# Altium schematic sheet Connector.SchDoc(Connector)
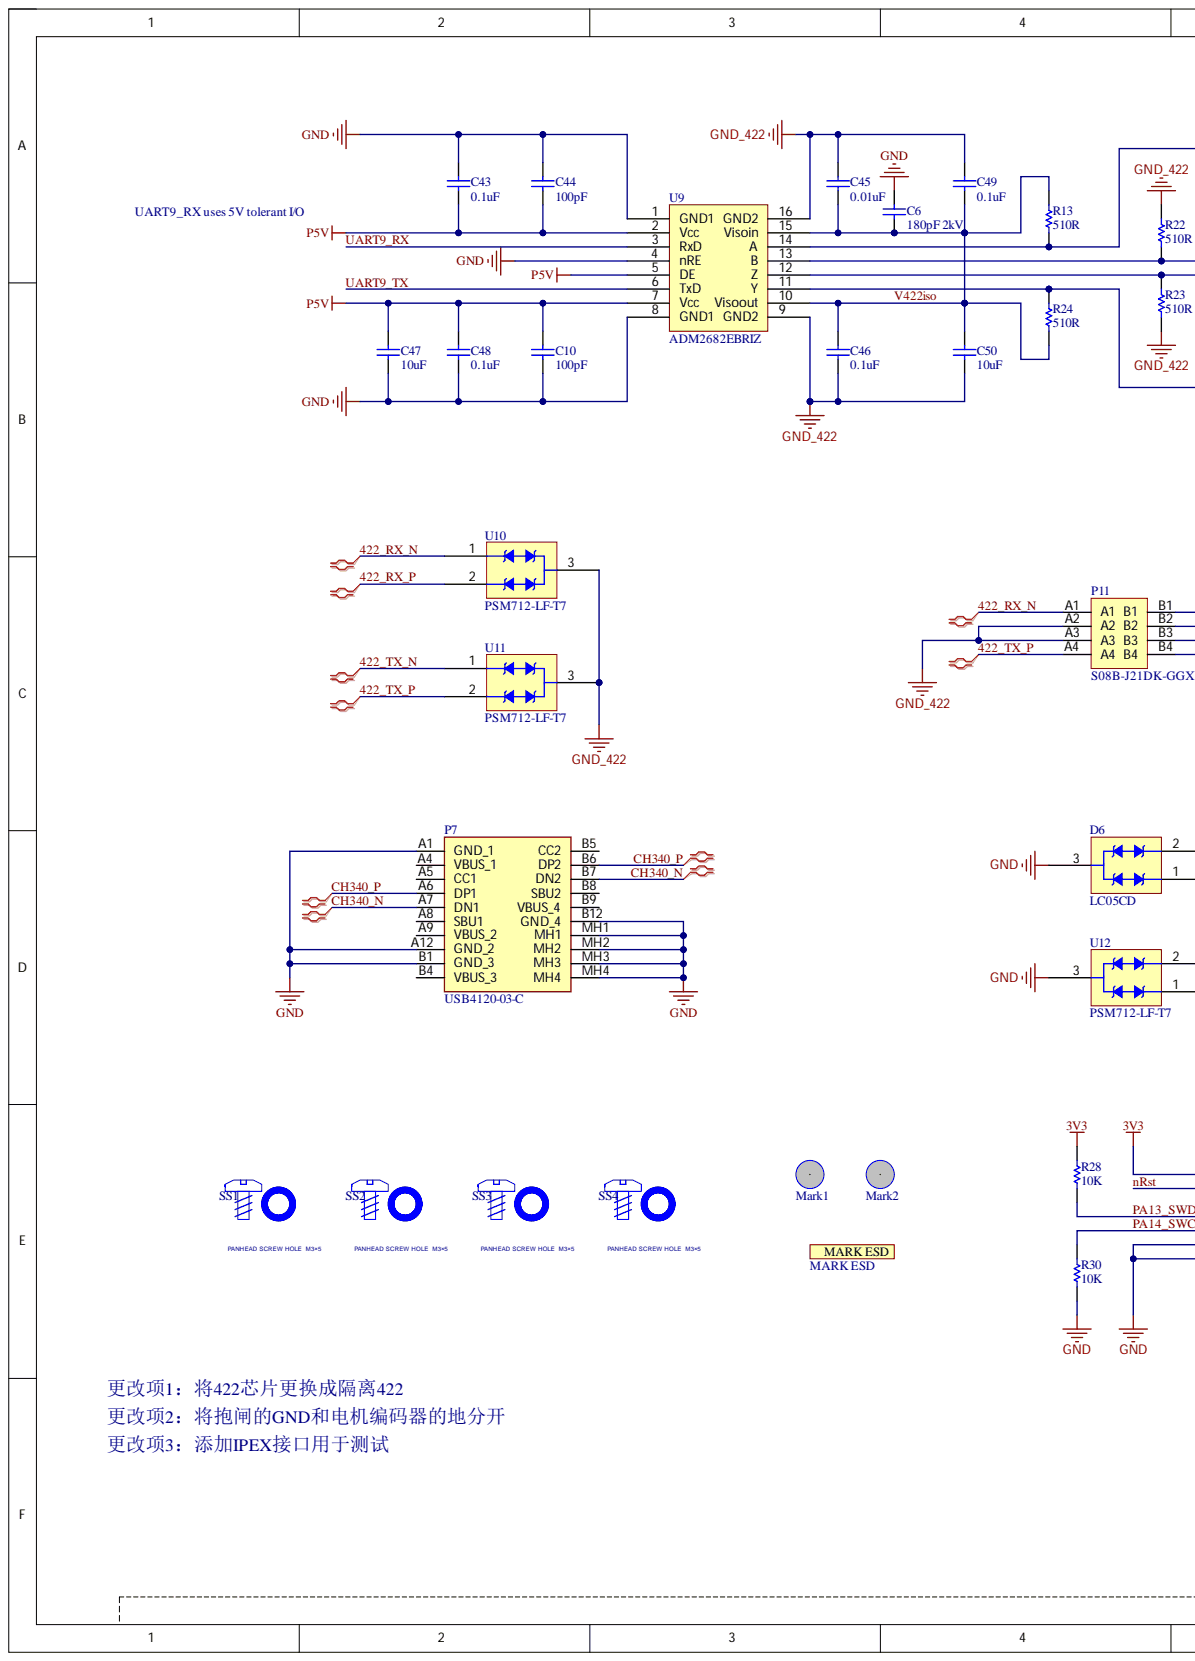
[diagram p0: Connector.SchDoc, left]
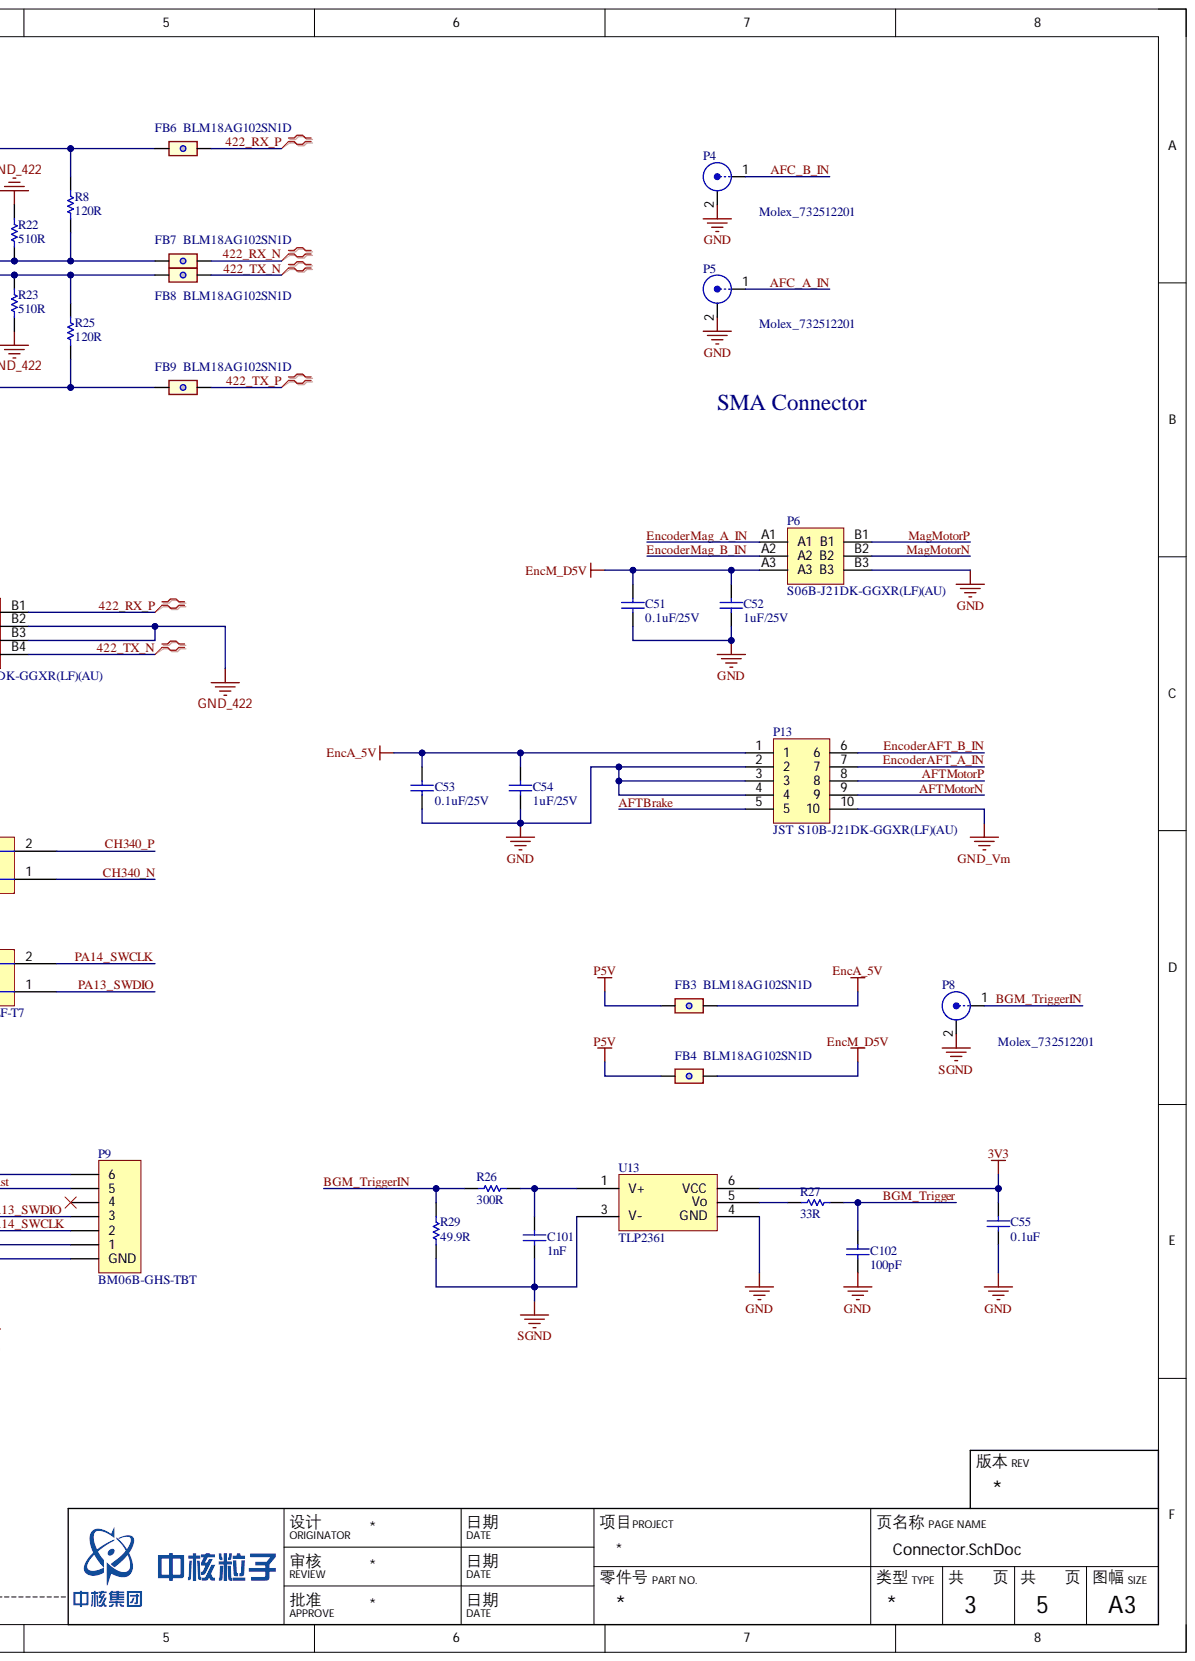
[diagram p1: Connector.SchDoc, right]

## Parts
C6  180pF 2kV  [C1206(3216)]
C10  100pF  [C0402(1005)]
C43  0.1uF  [C0402(1005)]
C44  100pF  [C0402(1005)]
C45  0.01uF  [C0402(1005)]
C46  0.1uF  [C0402(1005)]
C47  10uF  [C0402(1005)]
C48  0.1uF  [C0402(1005)]
C49  0.1uF  [C0402(1005)]
C50  10uF  [C0402(1005)]
C51  0.1uF/25V  [C0603(1608)]
C52  1uF/25V  [C0603(1608)]
C53  0.1uF/25V  [C0603(1608)]
C54  1uF/25V  [C0603(1608)]
C55  0.1uF  [C0603(1608)]
C101  1nF  [C0603(1608)]
C102  100pF  [C0603(1608)]
D6  LC05CD  [SOT23-3]
FB3  BLM18AG102SN1D  [FB0603(1608)]
FB4  BLM18AG102SN1D  [FB0603(1608)]
FB6  BLM18AG102SN1D  [FB0603(1608)]
FB7  BLM18AG102SN1D  [FB0603(1608)]
FB8  BLM18AG102SN1D  [FB0603(1608)]
FB9  BLM18AG102SN1D  [FB0603(1608)]
Mark1  Mark  [Mark]
Mark2  Mark  [Mark]
Mark_ESD  MARK ESD  [Mark ESD]
P4  Molex_732512201  [Molex_732512201]
P5  Molex_732512201  [Molex_732512201]
P6  S06B-J21DK-GGXR(LF)(AU)  [S06B-J21DK-GGXR]
P7  USB4120-03-C  [USB412003C]
P8  Molex_732512201  [Molex_732512201]
P9  BM06B-GHS-TBT  [BM06B-GHS-TBT]
P11  S08B-J21DK-GGXR(LF)(AU)  [S08B-J21DK-GGXR]
P13  JST  S10B-J21DK-GGXR(LF)(AU)  制造商: JST  型号: S10B-J21DK-GGXR(LF)(AU)  [JST S10B-J21DK-GGXR]
R8  120R  [R0402(1005)]
R13  510R  [R0402(1005)]
R22  510R  [R0402(1005)]
R23  510R  [R0402(1005)]
R24  510R  [R0402(1005)]
R25  120R  [R0402(1005)]
R26  300R  [R0603(1608)]
R27  33R  [R0603(1608)]
R28  10K  [R0603(1608)]
R29  49.9R  [R0603(1608)]
R30  10K  [R0603(1608)]
SS1  PANHEAD SCREW HOLE  M3×5  [MOUNTING_HOLE_3MM_RND]
SS2  PANHEAD SCREW HOLE  M3×5  [MOUNTING_HOLE_3MM_RND]
SS3  PANHEAD SCREW HOLE  M3×5  [MOUNTING_HOLE_3MM_RND]
SS4  PANHEAD SCREW HOLE  M3×5  [MOUNTING_HOLE_3MM_RND]
U9  ADM2682EBRIZ  [SOIC16_Body 10.3x7.5mm]
U10  PSM712-LF-T7  [SOT23-3]
U11  PSM712-LF-T7  [SOT23-3]
U12  PSM712-LF-T7  [SOT23-3]
U13  TLP2361  [SO5]

## Nets
3V3: C55.2 P9.6 R28.2 U13.6(VCC)
EncA_5V: C53.2 C54.2 FB3.2 P13.1
EncM_D5V: C51.2 C52.2 FB4.2 P6.A3
GND: C6.2 C10.1 C43.2 C44.2 C47.1 C48.1 C51.1 C52.1 C53.1 C54.1 C55.1 C102.1 D6.3 P4.2 P5.2 P6.B3 P7.A1(GND_1) P7.A12(GND_2) P7.B1(GND_3) P7.B12(GND_4) P7.MH1 P7.MH2 P7.MH3 P7.MH4 P9.1 P9.GND P13.2 P13.3 P13.4 R30.1 U9.1(GND1) U9.4(nRE) U9.8(GND1) U12.3 U13.4(GND)
GND_422: C45.2 C46.1 C49.2 C50.1 P11.A2 P11.A3 P11.B2 P11.B3 R22.2 R23.1 U9.9(GND2) U9.16(GND2) U10.3 U11.3
GND_Vm: P13.10
P5V: C10.2 C43.1 C44.1 C47.2 C48.2 FB3.1 FB4.1 U9.2(Vcc) U9.5(DE) U9.7(Vcc)
SGND: C101.1 P8.2 R29.1 U13.3(V-)
422_RX_N: FB7.2 P11.A1 U10.1
422_RX_P: FB6.2 P11.B1 U10.2
422_TX_N: FB8.2 P11.B4 U11.1
422_TX_P: FB9.2 P11.A4 U11.2
AFC_A_IN: P5.1
AFC_B_IN: P4.1
AFTBrake: P13.5
AFTMotorN: P13.9
AFTMotorP: P13.8
BGM_Trigger: C102.2 R27.2
BGM_TriggerIN: P8.1 R26.1 R29.2
CH340_N: D6.1 P7.A7(DN1) P7.B7(DN2)
CH340_P: D6.2 P7.A6(DP1) P7.B6(DP2)
EncoderAFT_A_IN: P13.7
EncoderAFT_B_IN: P13.6
EncoderMag_A_IN: P6.A1
EncoderMag_B_IN: P6.A2
MagMotorN: P6.B2
MagMotorP: P6.B1
nRst: P9.5
PA13_SWDIO: P9.3 R28.1 U12.1
PA14_SWCLK: P9.2 R30.2 U12.2
UART9_RX: U9.3(RxD)
UART9_TX: U9.6(TxD)
V422iso: C6.1 C45.1 C46.2 C49.1 C50.2 R13.1 R24.1 U9.10(Visoout) U9.15(Visoin)
NetC101_2: C101.2 R26.2 U13.1(V+)
NetFB6_1: FB6.1 R8.2 R13.2 U9.14(A)
NetFB7_1: FB7.1 R8.1 R22.1 U9.13(B)
NetFB8_1: FB8.1 R23.2 R25.2 U9.12(Z)
NetFB9_1: FB9.1 R24.2 R25.1 U9.11(Y)
NetR27_1: R27.1 U13.5(Vo)

Not connected: Mark1 1; Mark2 1; P7 A4(VBUS_1) A5(CC1) A8(SBU1) A9(VBUS_2) B4(VBUS_3) B5(CC2) B8(SBU2) B9(VBUS_4); P9 4

## Library data of the parts
C6 = Cap 180pF 1206 2kV: Ceramic 2kV; Component Kind: Standard; Library Name: RCL_temp.SchLib
C10 C44 = Cap 100pF 0402: Ceramic 50V; Component Kind: Standard; Library Name: RCL_release.SchLib
C43 C46 C48 C49 = Cap 0.1uF 0402-25V: ceramic 25V; 价格: ¥0.33@100; 制造商: KEMET; 型号: C0402C104M3RACTU; Component Kind: Standard; Library Name: RCL_release.SchLib; 供应商及库存编号: e络盟 2528342
C45 = Cap 0.01uF 0402-25V: ceramic 25V; 价格: ¥0.84@100; 制造商: KEMET; 型号: C0402C103K3RALTU; Component Kind: Standard; Library Name: RCL_release.SchLib; 供应商及库存编号: e络盟 2678263
C47 C50 = Cap 10uF 0402: ceremic 10V; Component Kind: Standard; Library Name: RCL_temp.SchLib
C51 C53 = Cap 0.1uF 0603-25V: ceramic 25V; 价格: cip¥0.092@100; 制造商: KEMET; 名称: 瓷片电容; 型号: C0603C104K3RACTU; Component Kind: Standard; Library Name: RCL_release.SchLib; 供应商及库存编号: e络盟 1650835
C52 C54 = Cap 1uF 0603-25V: ceramic 25V; 价格: ¥0.74@100; 制造商: KEMET; 名称: 瓷片电容; 型号: C0603C105K3RACTU; Component Kind: Standard; Library Name: RCL_release.SchLib; 供应商及库存编号: e络盟 2118128
C55 = Cap 0.1uF 0603-10V: ceramic 10V; 价格: ¥0.092@100; 制造商: MULTICOMP; 型号: MC0603B104K100CT; Component Kind: Standard; Library Name: RCL_release.SchLib; 供应商及库存编号: e络盟 2627427
C101 = Cap 1nF 0603-10V: ceramic 10V; 价格: ￥0.49@100; 制造商: KEMET; 型号: C0603C102K8RACTU; Component Kind: Standard; Library Name: RCL_release.SchLib; 供应商及库存编号: e络盟 2581040
C102 = Cap 100pF 0603-25V: ceramic 25V; 价格: ¥0.8@100; 制造商: KEMET; 型号: C0603C101K3GAC7867; Component Kind: Standard; Library Name: RCL_release.SchLib; 供应商及库存编号: e络盟 2773108
D6 = LC05CD: TVS FOR USB2.0; Component Kind: Standard; Library Name: Transistor_release.SchLib; Package: SOT-23(SOT-23-3); Supplier: LC; SuppliersPartNumber: C32677
FB3 FB4 FB6-FB9 = FB-1K@100MHz 0603: FERRITE BEAD 1 KOHM 0603 1LN; 价格: ¥0.25@100; 制造商: MURATA; 名称: 磁珠; Component Kind: Standard; Library Name: RCL_release.SchLib; 供应商及库存编号: e络盟 1515671; 型号: BLM18AG102SN1D
P4 P5 P8 = Molex_732512201: Molex_732512201 SMA Right angle; Component Kind: Standard; Library Name: Connector_temp.SchLib
P7 = USB4120-03-C: USB Connectors USB C Rec 3u" vert 16P SMT & TH stakes pegs T+R+C H = 9.25; Component Kind: Standard; Library Name: Connector_release.SchLib
P13 = JST  S10B-J21DK-GGXR(LF)(AU): 2*5，双排插座，间距2.5mm; 价格: 29; Component Kind: Standard; Library Name: Connector_release.SchLib; 供应商及库存编号: JST Shop 59393200
R8 R25 = Res 120R 0402: Component Kind: Standard; Description: Res 120R 0402; Library Name: RCL_temp.SchLib
R13 R22-R24 = Res 510R 0402: Res ±1%; Component Kind: Standard; Library Name: RCL_release.SchLib
R26 = Res 300R 0603: Res ±1%; Component Kind: Standard; Library Name: RCL_release.SchLib
R27 = Res 33R 0603: Res ±1%; 名称: 贴片电阻; Component Kind: Standard; Library Name: RCL_release.SchLib
R28 R30 = Res 10K 0603: Res ±1%; 名称: 贴片电阻; Component Kind: Standard; Library Name: RCL_release.SchLib
R29 = Res 49.9R 0603: Res ±1%; Component Kind: Standard; Library Name: RCL_release.SchLib
SS1-SS4 = Screw Standoff hole_3mm: Grounding the Mounting holes  3mm; Mfr_Name: Building Fasteners; Mfr_Part_Number: PMSSS 440 0038 PH; Note: SCREW FOR STANDOFF; Component Kind: Standard; Library Name: Connector_release.SchLib
U9 = ADM2682EBRIZ: 5 kV rms Signal and Power Isolated RS-485 Transceiver; Component Kind: Standard; Library Name: IC_temp.SchLib
U10-U12 = PSM712-LF-T7: TVS; Component Kind: Standard; ComponentLink1Description: 供应商链接; ComponentLink1URL: http://www.szlcsc.com/product/details_33642.html; Library Name: Transistor_release.SchLib; Package: SOT-23(SOT-23-3); Supplier: LC; SuppliersPartNumber: C32677
U13 = TLP2361: fast opticalcouplter invert logic toshiba; Component Kind: Standard; Library Name: IC_temp.SchLib
Mark1 Mark2 = Mark: Component Kind: Standard; Description: Mark; Library Name: Connector_temp.SchLib
Mark_ESD = MARK ESD: Component Kind: Standard; Library Name: Connector_release.SchLib
P6 = S06B-J21DK-GGXR(LF)(AU): Component Kind: Standard; Library Name: Connector_temp.SchLib
P9 = BM06B-GHS-TBT: Component Kind: Standard; Description: BM06B-GHS-TBT; Library Name: Connector2023.SchLib
P11 = S08B-J21DK-GGXR(LF)(AU): Component Kind: Standard; Library Name: Connector_temp.SchLib
